# Altium schematic sheet Power_USB.SchDoc(Power_USB)
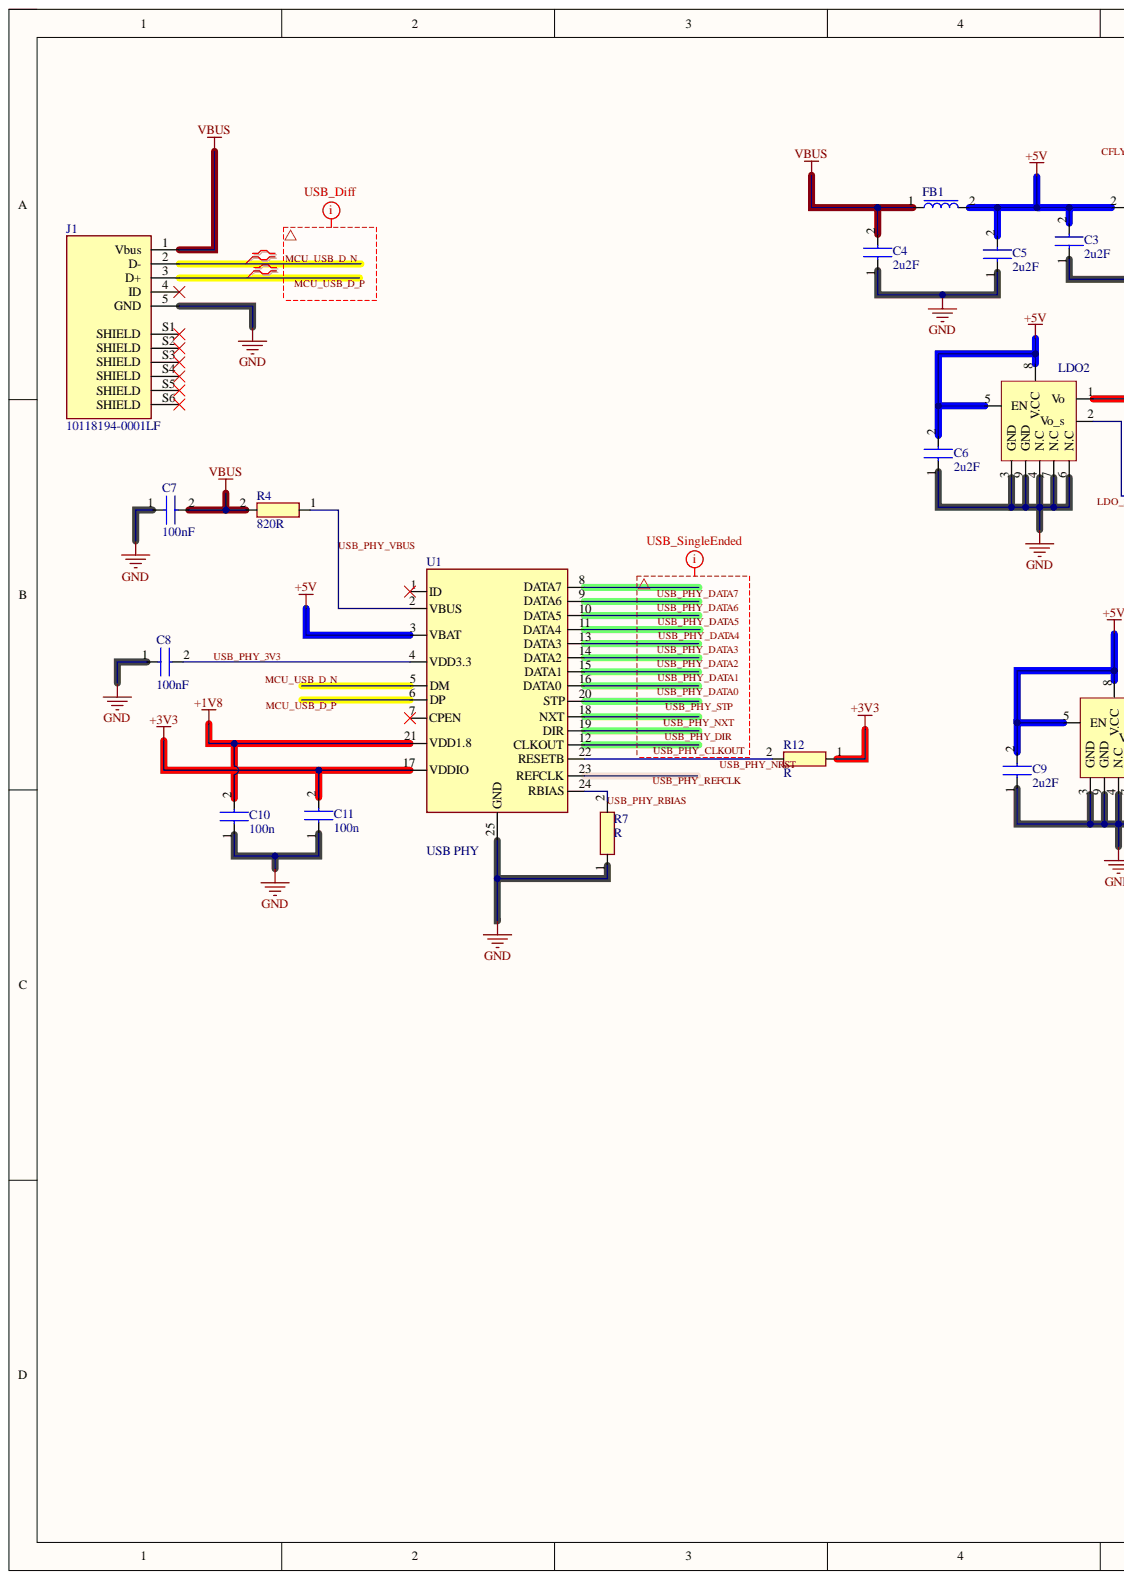
[diagram p0: Power_USB.SchDoc, left]
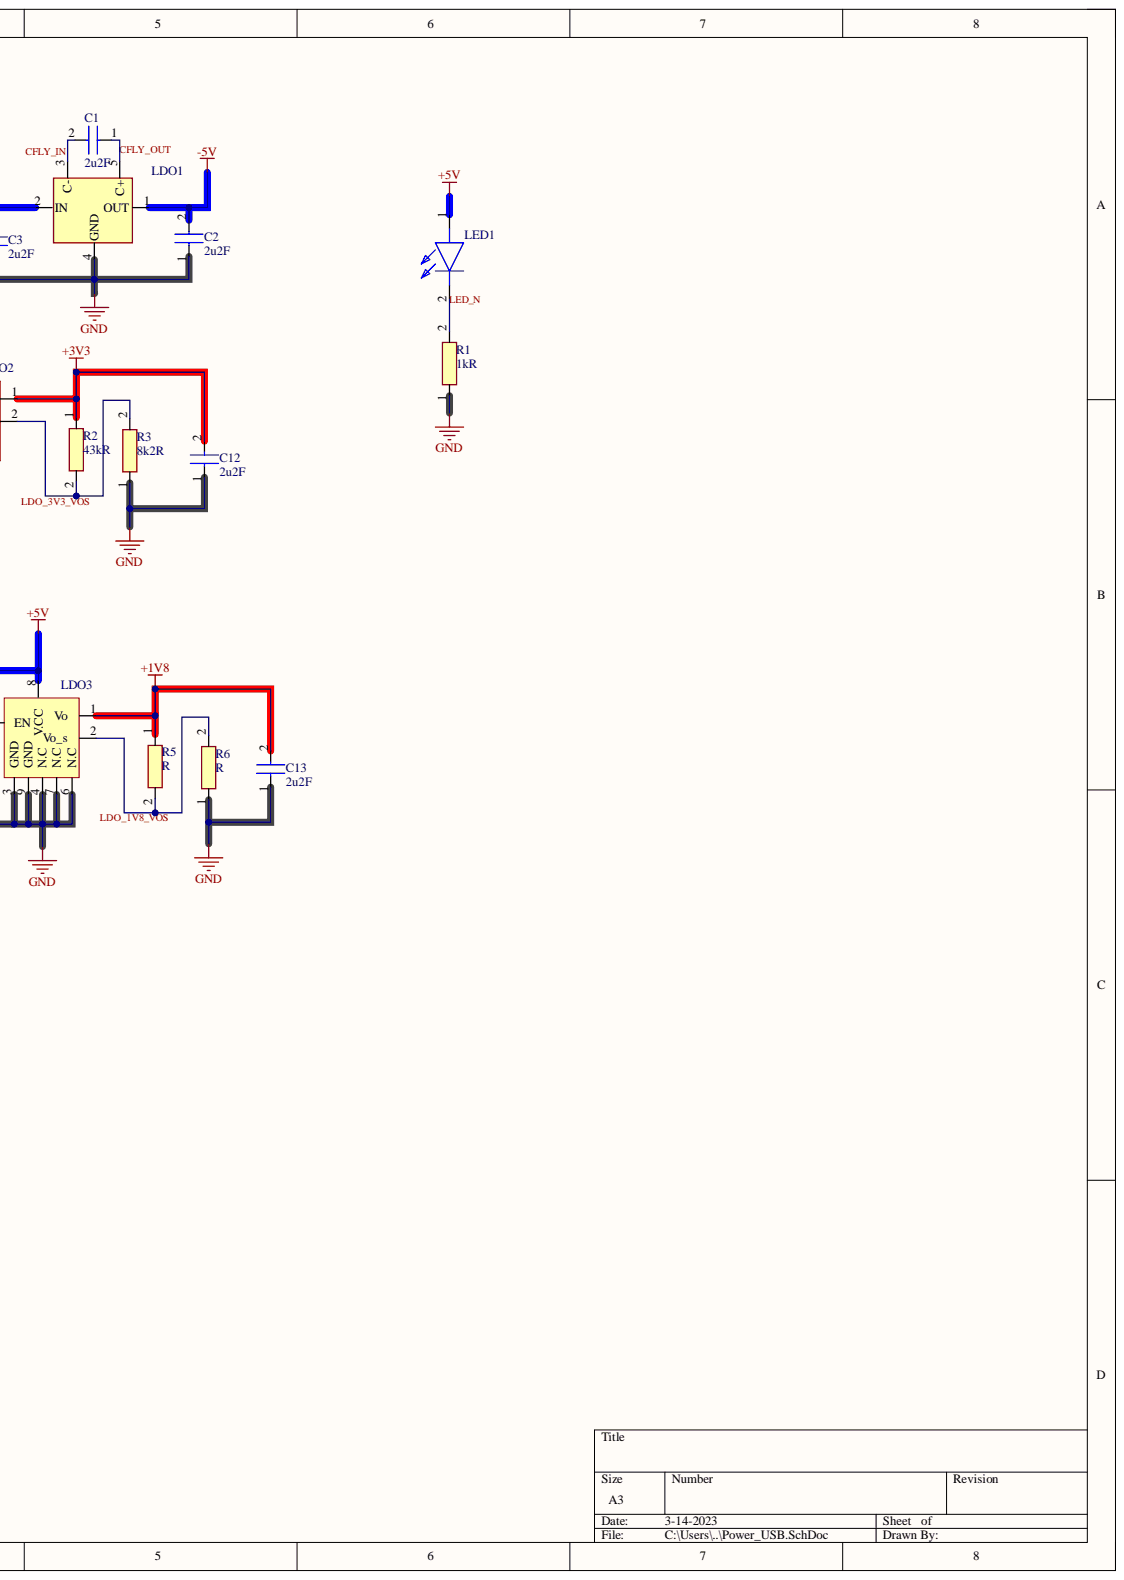
[diagram p1: Power_USB.SchDoc, right]

## Parts
C1  2u2F  [0603C]
C2  2u2F  [0603C]
C3  2u2F  [0603C]
C4  2u2F  [0603C]
C5  2u2F  [0603C]
C6  2u2F  [0603C]
C7  100nF  [0603C]
C8  100nF  [0603C]
C9  2u2F  [0603C]
C10  100n  [0603C]
C11  100n  [0603C]
C12  2u2F  [0603C]
C13  2u2F  [0603C]
FB1  Ferrite Bead @100R  [BEADC1608X90N]  (value not drawn)
J1  10118194-0001LF  [AMPHENOL_10118194-0001LF]
LDO1  -5V LDO  [TPS60400DBVR]  (value not drawn)
LDO2  LDO  [LDO]
LDO3  LDO  [LDO]
LED1  0603 LED Green  [0603 LED]  (value not drawn)
R1  1kR  [0603R]
R2  43kR  [0603R]
R3  8k2R  [0603R]
R4  820R  [0603R]
R5  R  [0603R]
R6  R  [0603R]
R7  R  [0603R]
R12  R  [0603R]
U1  USB PHY  [QFN50P400X400X100-25N-D]

## Nets
+1V8: C10.2 C13.2 LDO3.1(Vo) R5.1 U1.21(VDD1.8)
+3V3: C11.2 C12.2 LDO2.1(Vo) R2.1 R12.1 U1.17(VDDIO)
+5V: C3.2 C5.2 C6.2 C9.2 FB1.2 LDO1.2(IN) LDO2.5(EN) LDO2.8(V.CC) LDO3.5(EN) LDO3.8(V.CC) LED1.1 U1.3(VBAT)
-5V: C2.2 LDO1.1(OUT)
GND: C2.1 C3.1 C4.1 C5.1 C6.1 C7.1 C8.1 C9.1 C10.1 C11.1 C12.1 C13.1 J1.5(GND) LDO1.4(GND) LDO2.3(GND) LDO2.4(N.C) LDO2.6(N.C) LDO2.7(N.C) LDO2.9(GND) LDO3.3(GND) LDO3.4(N.C) LDO3.6(N.C) LDO3.7(N.C) LDO3.9(GND) R1.1 R3.1 R6.1 R7.1 U1.25(GND)
VBUS: C4.2 C7.2 FB1.1 J1.1(Vbus) R4.2
CFLY_IN: C1.2 LDO1.3(C-)
CFLY_OUT: C1.1 LDO1.5(C+)
LDO_1V8_VOS: LDO3.2(Vo_s) R5.2 R6.2
LDO_3V3_VOS: LDO2.2(Vo_s) R2.2 R3.2
LED_N: LED1.2 R1.2
MCU_USB_D_N: J1.2(D-) U1.5(DM)
MCU_USB_D_P: J1.3(D+) U1.6(DP)
USB_PHY_3V3: C8.2 U1.4(VDD3.3)
USB_PHY_CLKOUT: U1.12(CLKOUT)
USB_PHY_DATA0: U1.16(DATA0)
USB_PHY_DATA1: U1.15(DATA1)
USB_PHY_DATA2: U1.14(DATA2)
USB_PHY_DATA3: U1.13(DATA3)
USB_PHY_DATA4: U1.11(DATA4)
USB_PHY_DATA5: U1.10(DATA5)
USB_PHY_DATA6: U1.9(DATA6)
USB_PHY_DATA7: U1.8(DATA7)
USB_PHY_DIR: U1.19(DIR)
USB_PHY_NRST: R12.2 U1.22(RESETB)
USB_PHY_NXT: U1.18(NXT)
USB_PHY_RBIAS: R7.2 U1.24(RBIAS)
USB_PHY_REFCLK: U1.23(REFCLK)
USB_PHY_STP: U1.20(STP)
USB_PHY_VBUS: R4.1 U1.2(VBUS)

Not connected: J1 4(ID) S1(SHIELD) S2(SHIELD) S3(SHIELD) S4(SHIELD) S5(SHIELD) S6(SHIELD); U1 1(ID) 7(CPEN)

## Library data of the parts
C1-C13 = 0603C: Component Kind: Standard; Library Name: LIFI_BaseStation.SchLib
FB1 = Ferrite Bead @100R: Ferrite Bead; Manufacturer_Name: KEMET; Manufacturer_Part_Number: Z0603C101BPWZT; Arrow Part Number: Z0603C101BPWZT; Arrow Price/Stock: https://www.arrow.com/en/products/z0603c101bpwzt/kemet-corporation; Component Kind: Standard; Datasheet Link: https://api.kemet.com/component-edge/download/datasheet/Z0603C101BPWZT.pdf; Height: 0.9mm; Mouser Part Number: 80-Z0603C101BPWZT; Mouser Price/Stock: https://www.mouser.co.uk/ProductDetail/KEMET/Z0603C101BPWZT?qs=BYlwlsDsB%2FqttfRwYpxQxg%3D%3D; Library Name: LIFI_BaseStation.SchLib
J1 = USB_Micro_B_Female2: Micro Usb, 2.0 Type b, Rcpt, Smt; MF: {'manufacturer': u'AMPHENOL ICC / FCI'}; Availability: Unavailable; Component Kind: Standard; MP: 10118194-0001LF; Package: None; Price: None; Library Name: LIFI_BaseStation.SchLib
LDO1 = -5V LDO: Integrated Circuit; Manufacturer_Name: Texas Instruments; Arrow Part Number: TPS60400DBVR; Arrow Price/Stock: https://www.arrow.com/en/products/tps60400dbvr/texas-instruments?region=nac; Component Kind: Standard; Datasheet Link: http://www.ti.com/general/docs/suppproductinfo.tsp?distId=10&gotoUrl=http%3A%2F%2Fwww.ti.com%2Flit%2Fgpn%2Ftps60400; Height: 1.45mm; Manufacturer_Part_Number: TPS60400DBVR; Mouser Part Number: 595-TPS60400DBVR; Mouser Price/Stock: https://www.mouser.co.uk/ProductDetail/Texas-Instruments/TPS60400DBVR?qs=Gse6rAGbi7%2F9%252BEuIQ%2FZFVA%3D%3D; Library Name: LIFI_BaseStation.SchLib
LDO2 LDO3 = LDO: IC REG LDO ADJ 0.3A 8VSON; Manufacturer: Rohm; Manufacturer Part Number: BD00GA3WNUX-TR; Dropout Voltage: 450mV; Input Bias Current: 600uA; Max Input Voltage: 14V; Max Output Current: 300mA; Max Power Dissipation: 2.11W; Min Input Voltage: 4.5V; Number of Outputs: 1; Output Type: Adjustable; Output Voltage: 13V; Reference Voltage: 800mV; Category: Voltage Regulators - Linear; Component Kind: Standard; ComponentLink1Description: https://datasheet.ciiva.com/29570/bdxxga3wefj-e-29570298.pdf?src-supplier=Digi-Key; ComponentLink1URL: https://datasheet.ciiva.com/29570/bdxxga3wefj-e-29570298.pdf?src-supplier=Digi-Key; ComponentLink2Description: https://datasheet.ciiva.com/29570/bdxxga3wefj-e-29570299.pdf?src-supplier=Digi-Key; ComponentLink2URL: https://datasheet.ciiva.com/29570/bdxxga3wefj-e-29570299.pdf?src-supplier=Digi-Key; ComponentLink3Description: https://datasheet.ciiva.com/29570/bdxxga3wefj-e-29570297.pdf?src-supplier=Digi-Key; ComponentLink3URL: https://datasheet.ciiva.com/29570/bdxxga3wefj-e-29570297.pdf?src-supplier=Digi-Key; Lead Free: Lead Free; Max Operating Temperature: 85°C; Min Operating Temperature: -25°C; Mount: Surface Mount; Number of Regulators: 1; Output Current: 300mA; Package Quantity: 4000; Packaging: Tape and Reel; Pricing 1: 1=0.73000, 10=0.64100, 50=0.64100, 100=0.49100, 1000=0.31100, 10000=0.25600 (USD); RoHS: Yes; RoHS Compliant: Yes; Stock 1: 2744; Supplier 1: Mouser; Library Name: LIFI_BaseStation.SchLib
LED1 = 0603 LED Green: LED; Manufacturer_Name: Wurth Elektronik; Manufacturer_Part_Number: 150060VS75003; Component Kind: Standard; Datasheet Link: https://www.we-online.com/catalog/datasheet/150060VS75003.pdf; Height: 0.8mm; Mouser Part Number: 710-150060VS75003; Mouser Price/Stock: https://www.mouser.co.uk/ProductDetail/Wurth-Elektronik/150060VS75003?qs=Li%252BoUPsLEnv2ZkbQUMi3uQ%3D%3D; Library Name: LIFI_BaseStation.SchLib
R1-R7 R12 = 0603R: Component Kind: Standard; Library Name: LIFI_BaseStation.SchLib
U1 = USB PHY: Integrated Circuit; Manufacturer_Name: Microchip; Manufacturer_Part_Number: USB3318C-CP-TR; Arrow Part Number: USB3318C-CP-TR; Arrow Price/Stock: https://www.arrow.com/en/products/usb3318c-cp-tr/microchip-technology; Component Kind: Standard; Datasheet Link: http://www.microchip.com/mymicrochip/filehandler.aspx?ddocname=en562029; Height: 1mm; Mouser Part Number: 886-USB3318C-CP-TR; Mouser Price/Stock: https://www.mouser.co.uk/ProductDetail/Microchip-Technology/USB3318C-CP-TR?qs=pA5MXup5wxEqKRd0j%252Bo%252BXA%3D%3D; Library Name: LIFI_BaseStation.SchLib
